# Altium schematic sheet EIE_MCU_COM_v1.SchDoc
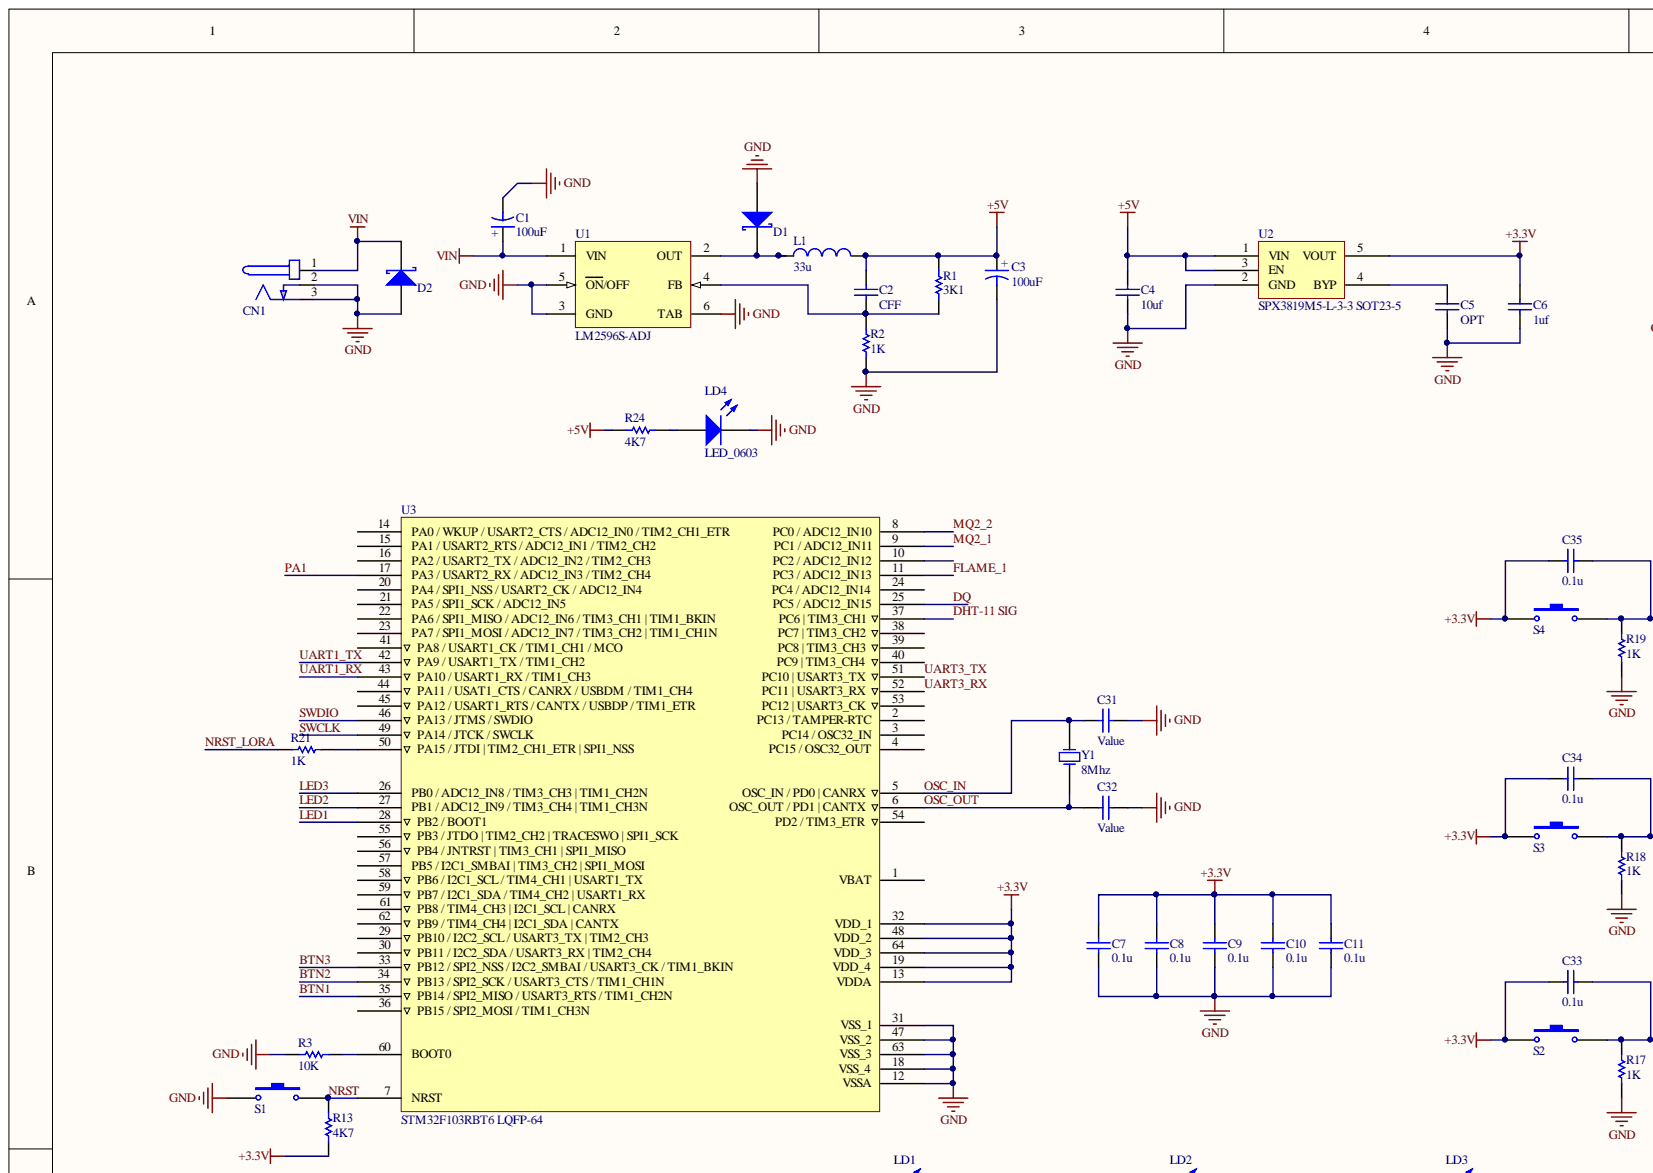
[diagram p0: EIE_MCU_COM_v1.SchDoc, top left]
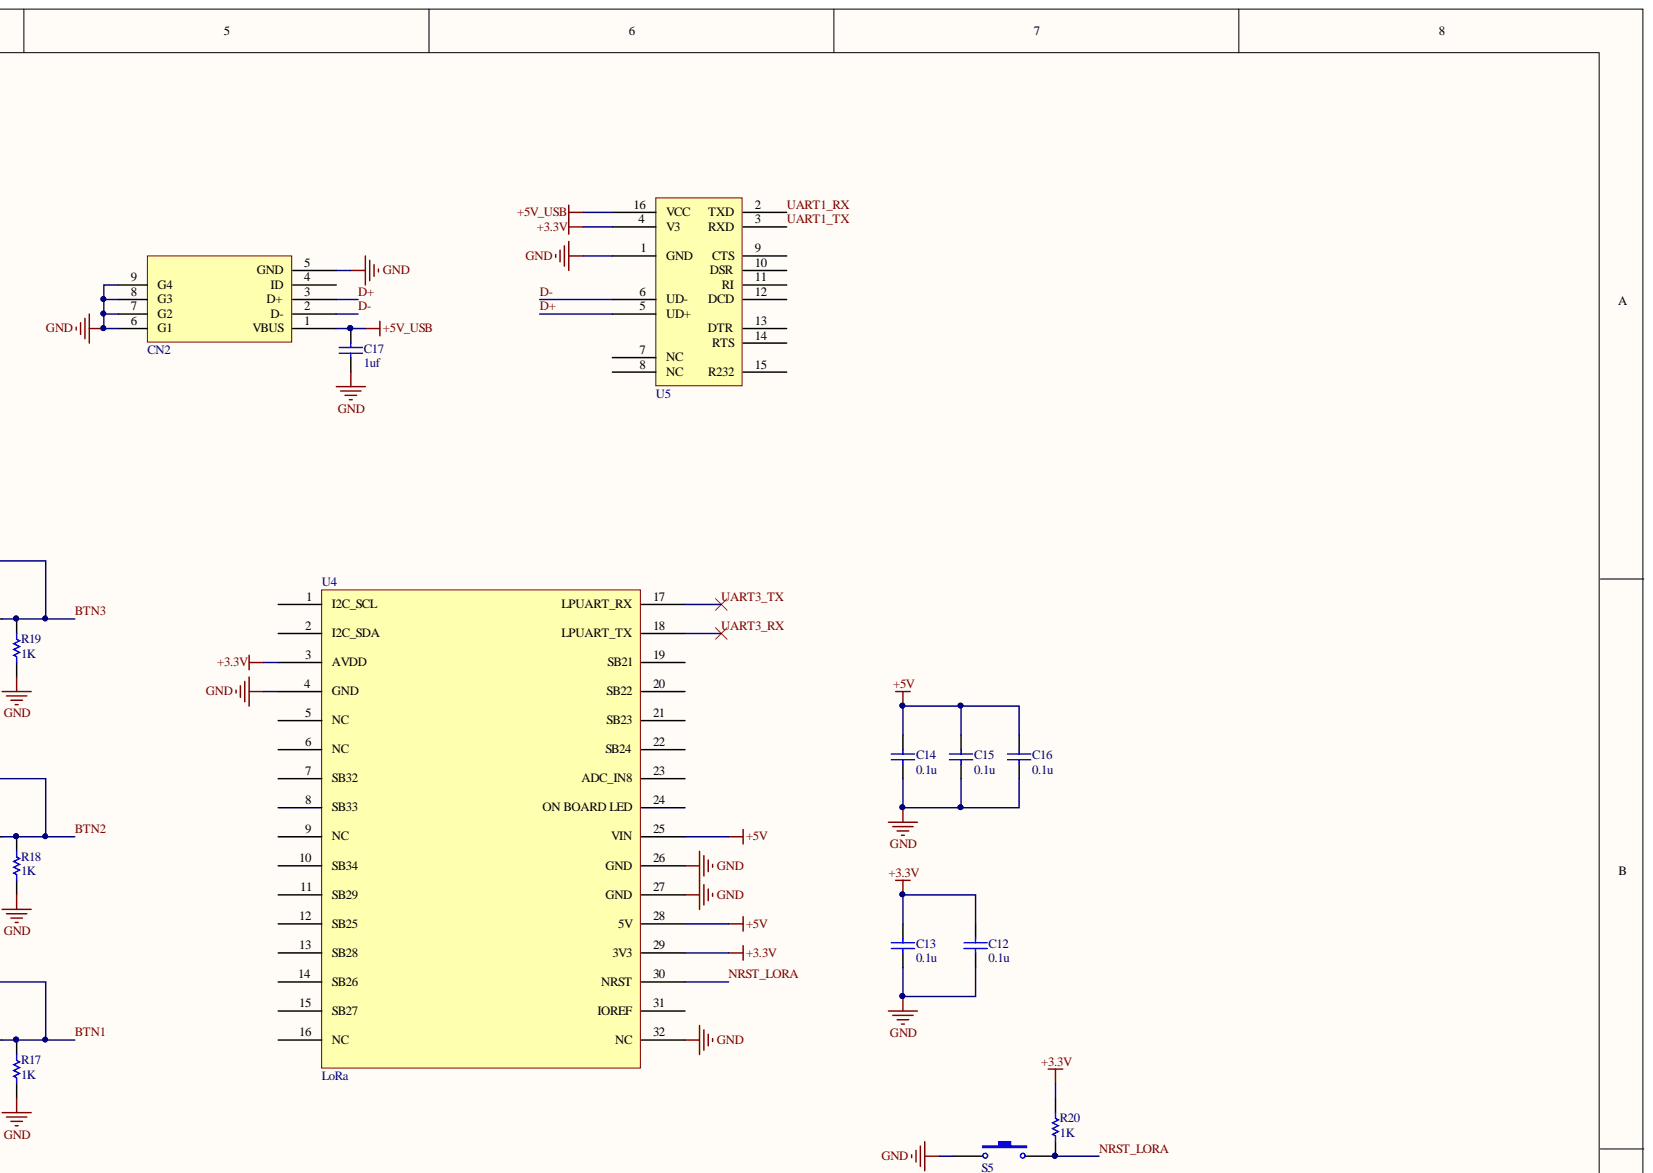
[diagram p1: EIE_MCU_COM_v1.SchDoc, top right]
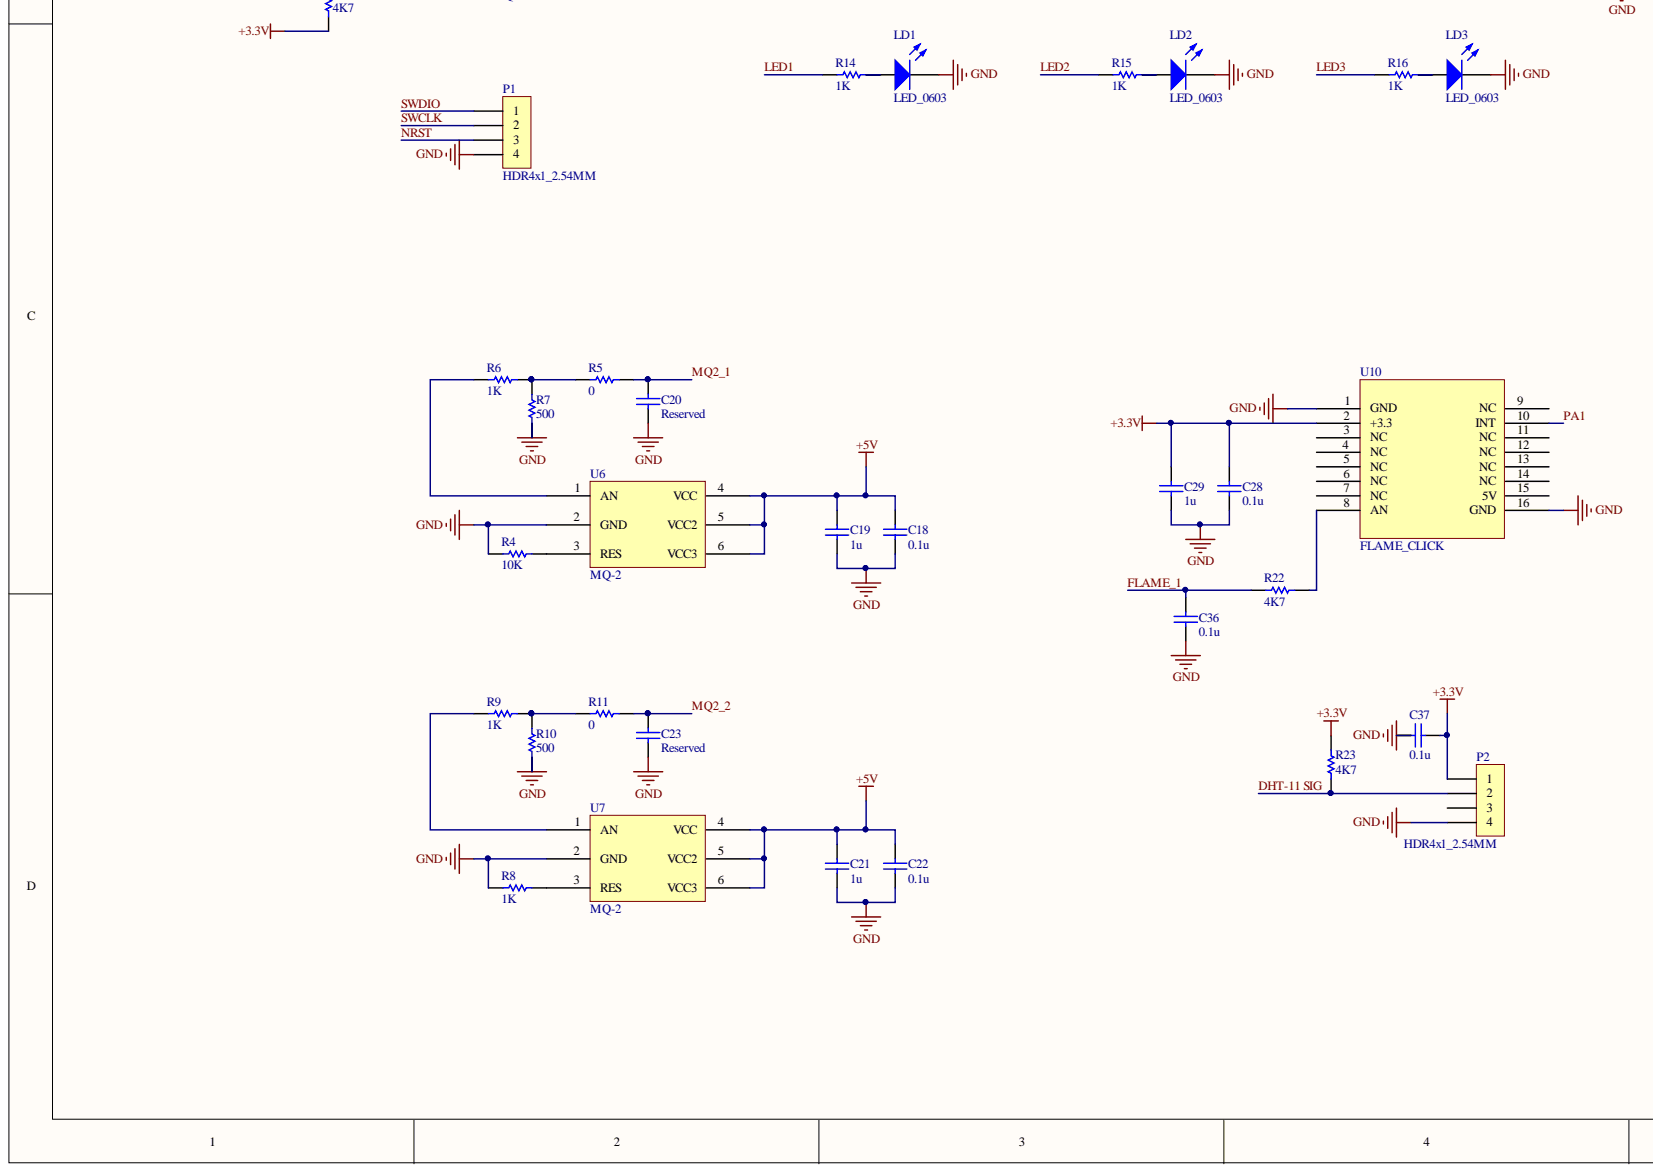
[diagram p2: EIE_MCU_COM_v1.SchDoc, bottom left]
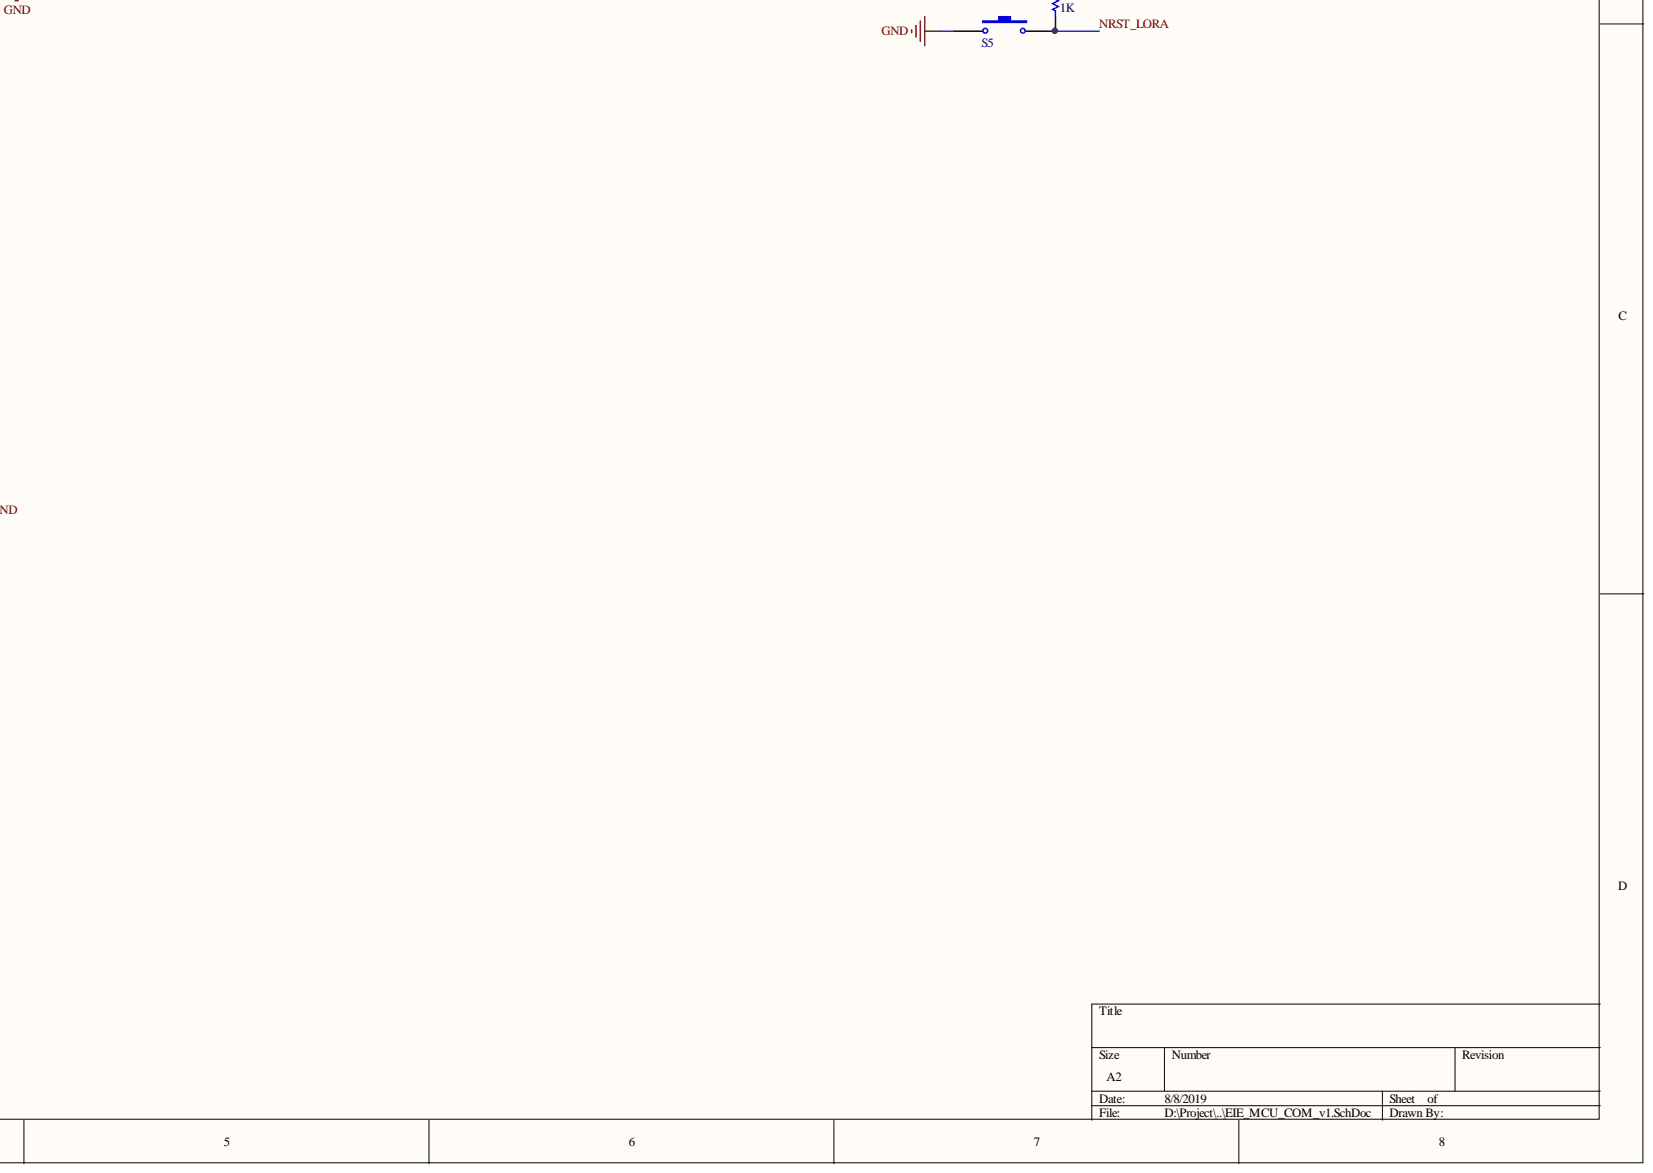
[diagram p3: EIE_MCU_COM_v1.SchDoc, bottom right]

## Parts
C1  100uF  [E-CAP_D8.2X16_LS3.2]
C2  CFF  [CAP_0603_SMD]
C3  100uF  [E-CAP_D8.2X16_LS3.2]
C4  10uf  [CAP_1206_SMD]
C5  OPT  [CAP_0603_SMD]
C6  1uf  [CAP_0603_SMD]
C7  0.1u  [CAP_0603_SMD]
C8  0.1u  [CAP_0603_SMD]
C9  0.1u  [CAP_0603_SMD]
C10  0.1u  [CAP_0603_SMD]
C11  0.1u  [CAP_0603_SMD]
C12  0.1u  [CAP_0603_SMD]
C13  0.1u  [CAP_0603_SMD]
C14  0.1u  [CAP_0603_SMD]
C15  0.1u  [CAP_0603_SMD]
C16  0.1u  [CAP_0603_SMD]
C17  1uf  [CAP_0603_SMD]
C18  0.1u  [CAP_0603_SMD]
C19  1u  [CAP_0603_SMD]
C20  Reserved  [CAP_0603_SMD]
C21  1u  [CAP_0603_SMD]
C22  0.1u  [CAP_0603_SMD]
C23  Reserved  [CAP_0603_SMD]
C28  0.1u  [CAP_0603_SMD]
C29  1u  [CAP_0603_SMD]
C31  Value  [CAP_0603_SMD]
C32  Value  [CAP_0603_SMD]
C33  0.1u  [CAP_0603_SMD]
C34  0.1u  [CAP_0603_SMD]
C35  0.1u  [CAP_0603_SMD]
C36  0.1u  [CAP_0603_SMD]
C37  0.1u  [CAP_0603_SMD]
CN1  PWR5.5x2.1  [PWR5.5x2.1]  (value not drawn)
CN2  MiniUSB Socket SMT  [MiniUSB Socket SMT]  (value not drawn)
D1  SS34_A  [1N5822 SS34 Type_A SMT]  (value not drawn)
D2  SS34_A  [1N5822 SS34 Type_A SMT]  (value not drawn)
L1  33u  [IND_CDRH104R_10MM]
LD1  LED_0603  [LED_0603]
LD2  LED_0603  [LED_0603]
LD3  LED_0603  [LED_0603]
LD4  LED_0603  [LED_0603]
P1  HDR4x1_2.54MM  [HDR4x1_2.54]
P2  HDR4x1_2.54MM  [HDR4x1_2.54]
R1  3K1  [RES_0603_SMD]
R2  1K  [RES_0603_SMD]
R3  10K  [RES_0603_SMD]
R4  10K  [RES_0603_SMD]
R5  0  [RES_0603_SMD]
R6  1K  [RES_0603_SMD]
R7  500  [RES_0603_SMD]
R8  1K  [RES_0603_SMD]
R9  1K  [RES_0603_SMD]
R10  500  [RES_0603_SMD]
R11  0  [RES_0603_SMD]
R13  4K7  [RES_0603_SMD]
R14  1K  [RES_0603_SMD]
R15  1K  [RES_0603_SMD]
R16  1K  [RES_0603_SMD]
R17  1K  [RES_0603_SMD]
R18  1K  [RES_0603_SMD]
R19  1K  [RES_0603_SMD]
R20  1K  [RES_0603_SMD]
R21  1K  [RES_0603_SMD]
R22  4K7  [RES_0603_SMD]
R23  4K7  [RES_0603_SMD]
R24  4K7  [RES_0603_SMD]
S1  PBTN_SMD_6x6x5_4P_BK  [PBTN_SMD_6x6x5_4P_BK]  (value not drawn)
S2  PBTN_SMD_6x6x5_4P_BK  [PBTN_SMD_6x6x5_4P_BK]  (value not drawn)
S3  PBTN_SMD_6x6x5_4P_BK  [PBTN_SMD_6x6x5_4P_BK]  (value not drawn)
S4  PBTN_SMD_6x6x5_4P_BK  [PBTN_SMD_6x6x5_4P_BK]  (value not drawn)
S5  PBTN_SMD_6x6x5_4P_BK  [PBTN_SMD_6x6x5_4P_BK]  (value not drawn)
U1  LM2596S-ADJ  [LM2596S]
U2  SPX3819M5-L-3-3 SOT23-5  [IC_SOT23-5]
U3  STM32F103RBT6 LQFP-64  [IC_LQFP-64]
U4  LoRa  [ST LoRa externsion]
U5  CH340C SOP-16  [IC_SOP-16]  (value not drawn)
U6  MQ-2  [PCBComponent_1]
U7  MQ-2  [PCBComponent_1]
U10  FLAME_CLICK  [PCBComponent_1]
Y1  8Mhz  [XTAL_SMD]

## Nets
+3.3V: C6.2 C7.2 C8.2 C9.2 C10.2 C11.2 C12.2 C13.2 C28.2 C29.2 C33.2 C34.2 C35.2 C37.2 P2.1 R13.2 R20.1 R23.2 S2.1 S3.1 S4.1 U2.5(VOUT) U3.13(VDDA) U3.19(VDD_4) U3.32(VDD_1) U3.48(VDD_2) U3.64(VDD_3) U4.3(AVDD) U4.29(3V3) U5.4(V3) U10.2(+3.3)
+5V: C2.2 C3.1 C4.2 C14.2 C15.2 C16.2 C18.2 C19.2 C21.2 C22.2 L1.2 R1.2 R24.2 U2.1(VIN) U2.3(EN) U4.25(VIN) U4.28(5V) U6.4(VCC) U6.5(VCC2) U6.6(VCC3) U7.4(VCC) U7.5(VCC2) U7.6(VCC3)
+5V_USB: C17.2 CN2.1(VBUS) U5.16(VCC)
GND: C1.2 C3.2 C4.1 C5.1 C6.1 C7.1 C8.1 C9.1 C10.1 C11.1 C12.1 C13.1 C14.1 C15.1 C16.1 C17.1 C18.1 C19.1 C20.1 C21.1 C22.1 C23.1 C28.1 C29.1 C31.1 C32.1 C36.1 C37.1 CN1.2 CN1.3 CN2.5(GND) CN2.6(G1) CN2.7(G2) CN2.8(G3) CN2.9(G4) D1.1 D2.1 LD1.2 LD2.2 LD3.2 LD4.2 P1.4 P2.4 R2.1 R3.2 R4.1 R7.1 R8.1 R10.1 R17.2 R18.2 R19.2 S1.1 S5.1 U1.3(GND) U1.5(ON/OFF) U1.6(TAB) U2.2(GND) U3.12(VSSA) U3.18(VSS_4) U3.31(VSS_1) U3.47(VSS_2) U3.63(VSS_3) U4.4(GND) U4.26(GND) U4.27(GND) U4.32(NC) U5.1(GND) U6.2(GND) U7.2(GND) U10.1(GND) U10.16(GND)
VIN: C1.1 CN1.1 D2.2 U1.1(VIN)
BTN1: C33.1 R17.1 S2.2 U3.35(PB14_/_SPI2_MISO_/_USART3_RTS_/_TIM1_CH2N)
BTN2: C34.1 R18.1 S3.2 U3.34(PB13_/_SPI2_SCK_/_USART3_CTS_/_TIM1_CH1N)
BTN3: C35.1 R19.1 S4.2 U3.33
D+: CN2.3(D+) U5.5(UD+)
D-: CN2.2(D-) U5.6(UD-)
DHT-11 SIG: P2.2 R23.1 U3.37(PC6_|_TIM3_CH1)
DQ: U3.25(PC5_/_ADC12_IN15)
FLAME_1: C36.2 R22.1 U3.11(PC3_/_ADC12_IN13)
LED1: R14.1 U3.28(PB2_/_BOOT1)
LED2: R15.1 U3.27(PB1_/_ADC12_IN9_/_TIM3_CH4_|_TIM1_CH3N)
LED3: R16.1 U3.26(PB0_/_ADC12_IN8_/_TIM3_CH3_|_TIM1_CH2N)
MQ2_1: C20.2 R5.2 U3.9(PC1_/_ADC12_IN11)
MQ2_2: C23.2 R11.2 U3.8(PC0_/_ADC12_IN10)
NRST: P1.3 R13.1 S1.2 U3.7(NRST)
NRST_LORA: R20.2 R21.1 S5.2 U4.30(NRST)
OSC_IN: C31.2 U3.5(OSC_IN_/_PD0_|_CANRX) Y1.2
OSC_OUT: C32.2 U3.6(OSC_OUT_/_PD1_|_CANTX) Y1.1
PA1: U3.17(PA3_/_USART2_RX_/_ADC12_IN3_/_TIM2_CH4) U10.10(INT)
SWCLK: P1.2 U3.49(PA14_/_JTCK_/_SWCLK)
SWDIO: P1.1 U3.46(PA13_/_JTMS_/_SWDIO)
UART1_RX: U3.43(PA10_/_USART1_RX_/_TIM1_CH3) U5.2(TXD)
UART1_TX: U3.42(PA9_/_USART1_TX_/_TIM1_CH2) U5.3(RXD)
UART3_RX: U3.52(PC11_|_USART3_RX) U4.18(LPUART_TX)
UART3_TX: U3.51(PC10_|_USART3_TX) U4.17(LPUART_RX)
NetC2_1: C2.1 R1.1 R2.2 U1.4(FB)
NetC5_2: C5.2 U2.4(BYP)
NetD1_2: D1.2 L1.1 U1.2(OUT)
NetLD1_1: LD1.1 R14.2
NetLD2_1: LD2.1 R15.2
NetLD3_1: LD3.1 R16.2
NetLD4_1: LD4.1 R24.1
NetR3_1: R3.1 U3.60(BOOT0)
NetR4_2: R4.2 U6.3(RES)
NetR5_1: R5.1 R6.2 R7.2
NetR6_1: R6.1 U6.1(AN)
NetR8_2: R8.2 U7.3(RES)
NetR9_1: R9.1 U7.1(AN)
NetR9_2: R9.2 R10.2 R11.1
NetR21_2: R21.2 U3.50(PA15_/_JTDI_|_TIM2_CH1_ETR_|_SPI1_NSS)
NetR22_2: R22.2 U10.8(AN)

Not connected: CN2 4(ID); P2 3; U3 1(VBAT) 2(PC13_/_TAMPER-RTC) 3(PC14_/_OSC32_IN) 4(PC15_/_OSC32_OUT) 10(PC2_/_ADC12_IN12) 14 15(PA1_/_USART2_RTS_/_ADC12_IN1_/_TIM2_CH2) 16(PA2_/_USART2_TX_/_ADC12_IN2_/_TIM2_CH3) 20(PA4_/_SPI1_NSS_/_USART2_CK_/_ADC12_IN4) 21(PA5_/_SPI1_SCK_/_ADC12_IN5) 22 23 24(PC4_/_ADC12_IN14) 29(PB10_/_I2C2_SCL_/_USART3_TX_|_TIM2_CH3) 30(PB11_/_I2C2_SDA_/_USART3_RX_|_TIM2_CH4) 36(PB15_/_SPI2_MOSI_/_TIM1_CH3N) 38(PC7_|_TIM3_CH2) 39(PC8_|_TIM3_CH3) 40(PC9_|_TIM3_CH4) 41(PA8_/_USART1_CK_/_TIM1_CH1_/_MCO) 44 45 53(PC12_|_USART3_CK) 54(PD2_/_TIM3_ETR) 55(PB3_/_JTDO_|_TIM2_CH2_|_TRACESWO_|_SPI1_SCK) 56(PB4_/_JNTRST_|_TIM3_CH1_|_SPI1_MISO) 57(PB5_/_I2C1_SMBAI_|_TIM3_CH2_|_SPI1_MOSI) 58(PB6_/_I2C1_SCL_/_TIM4_CH1_|_USART1_TX) 59(PB7_/_I2C1_SDA_/_TIM4_CH2_|_USART1_RX) 61(PB8_/_TIM4_CH3_|_I2C1_SCL_|_CANRX) 62(PB9_/_TIM4_CH4_|_I2C1_SDA_|_CANTX); U4 1(I2C_SCL) 2(I2C_SDA) 5(NC) 6(NC) 7(SB32) 8(SB33) 9(NC) 10(SB34) 11(SB29) 12(SB25) 13(SB28) 14(SB26) 15(SB27) 16(NC) 19(SB21) 20(SB22) 21(SB23) 22(SB24) 23(ADC_IN8) 24(ON_BOARD_LED) 31(IOREF); U5 7(NC) 8(NC) 9(CTS) 10(DSR) 11(RI) 12(DCD) 13(DTR) 14(RTS) 15(R232); U10 3(NC) 4(NC) 5(NC) 6(NC) 7(NC) 9(NC) 11(NC) 12(NC) 13(NC) 14(NC) 15(5V)

## Library data of the parts
C1 C3 = E-CAP_D8.2X16_LS3.2: Component Kind: Standard; Description: E-CAP_D8.2X16_LS3.2; Library Name: myRLC.SchLib; PackageReference: CAPR5-4X5; Published: 23-Sep-2002; Publisher: Altium Limited
C2 C5-C23 C28 C29 C31-C37 = CAP_0603_SMD: Capacitor, 0603, SMD; Component Kind: Standard; LatestRevisionDate: 17-Jul-2002; LatestRevisionNote: Re-released for DXP Platform.; Library Name: myRLC.SchLib; PackageReference: RAD-0.3; Published: 8-Jun-2000; Publisher: Altium Limited
C4 = CAP_1206_SMD: Capacitor, 1206, SMD; Component Kind: Standard; LatestRevisionDate: 17-Jul-2002; LatestRevisionNote: Re-released for DXP Platform.; Library Name: myRLC.SchLib; PackageReference: RAD-0.3; Published: 8-Jun-2000; Publisher: Altium Limited
CN1 = PWR5.5x2.1: DC Socket, 5.5x2.1; Component Kind: Standard; Library Name: myConnectors.SchLib
CN2 = MiniUSB Socket SMT: MicroUSB Socket, SMT; Component Kind: Standard; Library Name: myConnectors.SchLib
D1 D2 = 1N5822 SS34 Type_A SMT: Code_JEDEC: DO-214-AA; Component Kind: Standard; Description: 1N5822 SS34 Type_A SMT; LatestRevisionDate: 17-Jul-2002; LatestRevisionNote: Re-released for DXP Platform.; Library Name: myTransistors&Diodes.SchLib; PackageReference: SMB; Published: 8-Jun-2000; Publisher: Altium Limited
L1 = IND_CDRH104R_10MM: Inductor, CDRH104R, 10MM; Code_IEC: 1005; Code_JEITA: 0402; Component Kind: Standard; LatestRevisionDate: 29-May-2009; LatestRevisionNote: IPC-7351 Footprint Added.; Library Name: myRLC.SchLib; PackageDescription: Chip Inductor; PackageReference: 0402-A; Published: 8-Jun-2000; Value: Value; Publisher: Altium Limited
LD1-LD4 = LED_0603: LED 0603; Component Kind: Standard; LatestRevisionDate: 08-Jul-2005; LatestRevisionNote: Mech Layer 1 removed.; Library Name: myTransistors&Diodes.SchLib; PackageReference: LED-0; Published: 8-Jun-2000; Publisher: Altium Limited
P1 P2 = HDR4x1_2.54: Header, 4-Pin, 1-Row, 2.54mm Pitch; Component Kind: Standard; LatestRevisionDate: 17-Jul-2002; LatestRevisionNote: Re-released for DXP Platform.; Library Name: myConnectors.SchLib; Publisher: Altium Limited
R1-R11 R13-R24 = RES_0603_SMD: Resistor, 0603, SMD; Component Kind: Standard; LatestRevisionDate: 17-Jul-2002; LatestRevisionNote: Re-released for DXP Platform.; Library Name: myRLC.SchLib; PackageReference: AXIAL-0.3; Published: 8-Jun-2000; Value: Value; Publisher: Altium Limited
S1-S5 = PBTN_SMD_6x6x5_4P_BK: Switch; Component Kind: Standard; LatestRevisionDate: 17-Jul-2002; LatestRevisionNote: Re-released for DXP Platform.; Library Name: mySwitches.SchLib; PackageReference: SPST-2; Published: 24-Mar-1999; Publisher: Altium Limited
U1 = LM2596S-ADJ: SIMPLE SWITCHER Power Converter 150 KHz 3A Step-Down Voltage Regulator; Manufacturer: National Semiconductor; PackageTopMarking: NSUZXYTT*LM2596S*-ADJ P+; PowerWise: N; Code_JEDEC: MO-169-AB; Component Kind: Standard; ComponentLink1Description: LM2596 Datasheet; ComponentLink1URL: http://www.national.com/ds/LM/LM2596.pdf; ComponentLink2Description: LM2596 Web Page; ComponentLink2URL: http://www.national.com/pf/LM/LM2596.html; ComponentLink3Description: TS5B Package Page; ComponentLink3URL: http://www.national.com/packaging/folders/ts5b.html; CompType: Switcher; DatasheetVersion: May-2002; DigiKeyPartNumber: LM2596S-ADJ-ND; FirstPublished: 4-Dec-2008; Library Name: myICs.SchLib; PackageDescription: TO-263, Molded, .400 x .390 x .180in, 5 Lead, .067in Pitch, Surface Mount; PackageReference: TS5B; PackageVersion: revD, Apr-2005; PartNumber: LM2596S-ADJ; PinCount: 5; RoHS: O; Publisher: Altium Limited
U2 = SPX3819M5-L-3-3 SOT23-5: VREG, 3.3V, 500mA; Component Kind: Standard; Library Name: myICs.SchLib
U3 = STM32F103RBT6 LQFP-64: STM32 ARM-based 32-bit MCU with 128 Kbytes Flash, 64-pin LQFP, Industrial Temperature; Component Kind: Standard; Library Name: myICs.SchLib
U4 U6 U7 U10 = Component_1: Component Kind: Standard
  U6 U7: Library Name: MQ-2.SchLib
  U4: Library Name: ST_LoRa_extension.SchLib
  U10: Library Name: FrameSensor.SchLib
U5 = CH340C SOP-16: USB to Serial Chip; Component Kind: Standard; Library Name: myICs.SchLib
Y1 = XTAL_SMD: Crystal Oscillator; Component Kind: Standard; LatestRevisionDate: 17-Jul-2002; LatestRevisionNote: Re-released for DXP Platform.; Library Name: myMISC.SchLib; PackageReference: R38; Published: 8-Jun-2000; Publisher: Altium Limited
